# Altium schematic sheet 10 ADC.SchDoc
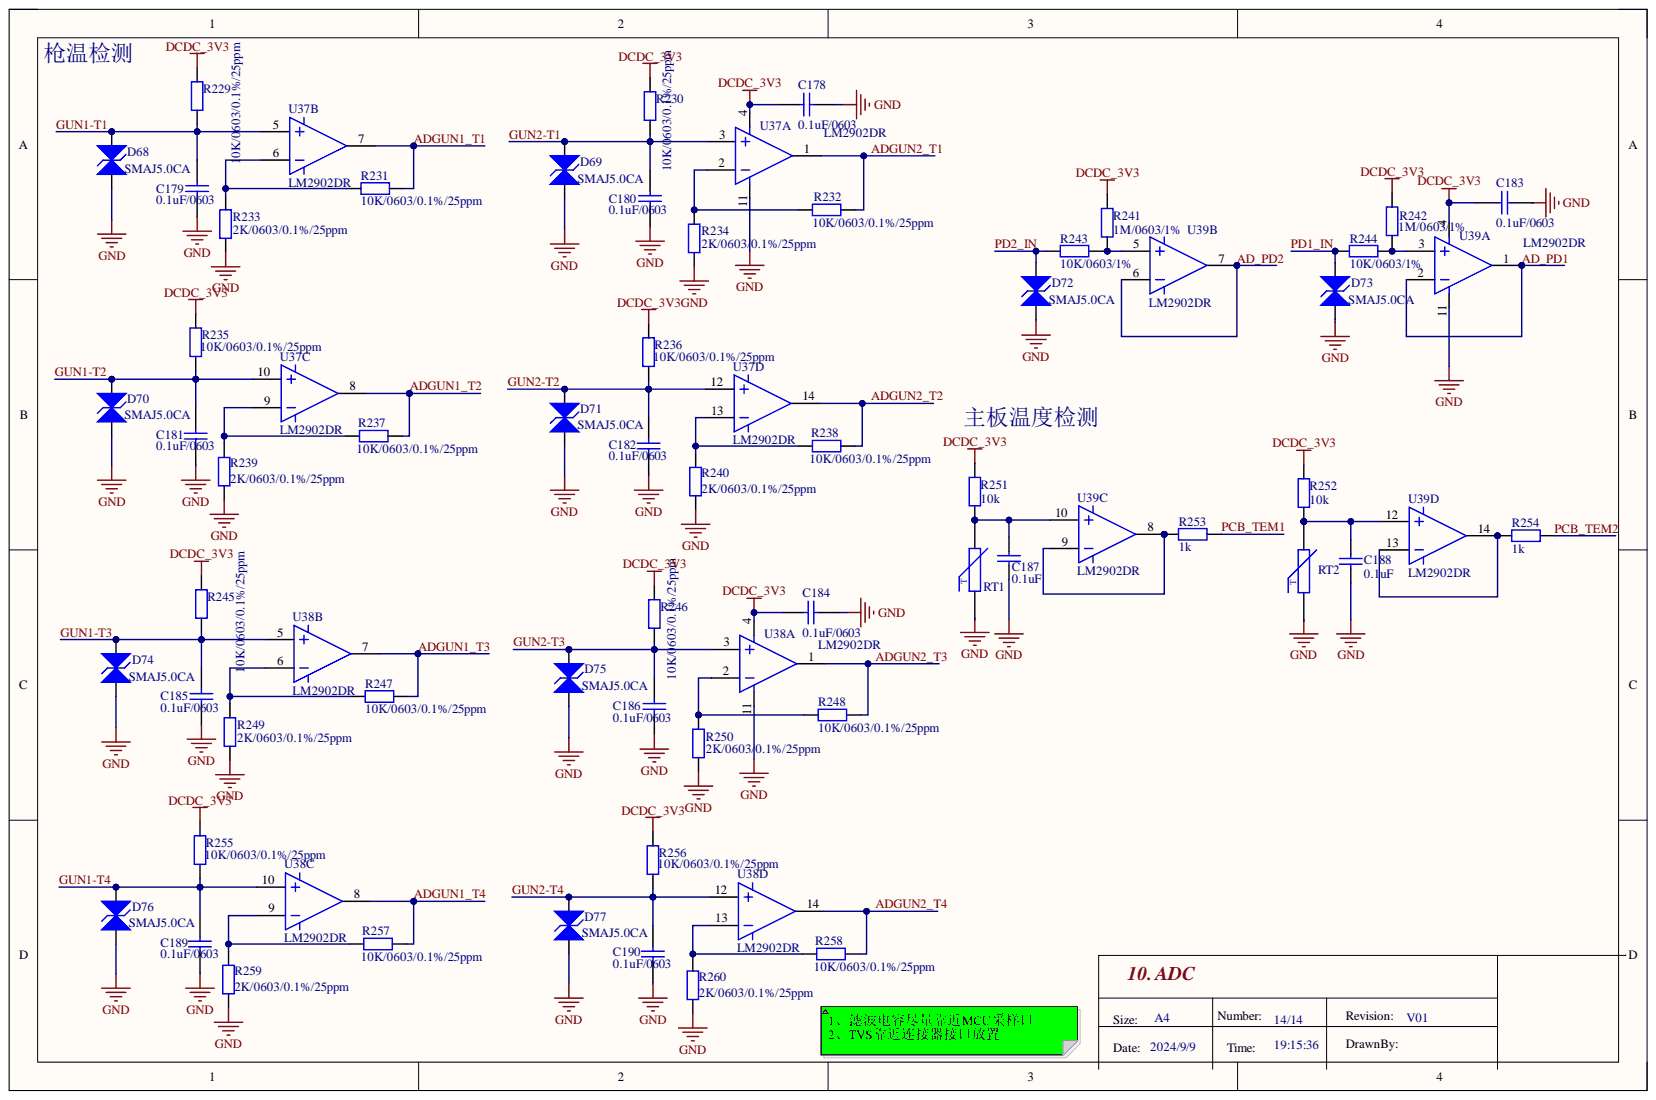
[diagram p0: 10 ADC.SchDoc]

## Parts
C178  0.1uF/0603  [C0603]
C179  0.1uF/0603  [C0603]
C180  0.1uF/0603  [C0603]
C181  0.1uF/0603  [C0603]
C182  0.1uF/0603  [C0603]
C183  0.1uF/0603  [C0603]
C184  0.1uF/0603  [C0603]
C185  0.1uF/0603  [C0603]
C186  0.1uF/0603  [C0603]
C187  0.1uF  [C0603]
C188  0.1uF  [C0603]
C189  0.1uF/0603  [C0603]
C190  0.1uF/0603  [C0603]
D68  SMAJ5.0CA  [SMA]
D69  SMAJ5.0CA  [SMA]
D70  SMAJ5.0CA  [SMA]
D71  SMAJ5.0CA  [SMA]
D72  SMAJ5.0CA  [SMA]
D73  SMAJ5.0CA  [SMA]
D74  SMAJ5.0CA  [SMA]
D75  SMAJ5.0CA  [SMA]
D76  SMAJ5.0CA  [SMA]
D77  SMAJ5.0CA  [SMA]
R229  10K/0603/0.1%/25ppm  [R0603]
R230  10K/0603/0.1%/25ppm  [R0603]
R231  10K/0603/0.1%/25ppm  [R0603]
R232  10K/0603/0.1%/25ppm  [R0603]
R233  2K/0603/0.1%/25ppm  [R0603]
R234  2K/0603/0.1%/25ppm  [R0603]
R235  10K/0603/0.1%/25ppm  [R0603]
R236  10K/0603/0.1%/25ppm  [R0603]
R237  10K/0603/0.1%/25ppm  [R0603]
R238  10K/0603/0.1%/25ppm  [R0603]
R239  2K/0603/0.1%/25ppm  [R0603]
R240  2K/0603/0.1%/25ppm  [R0603]
R241  1M/0603/1%  [R0603]
R242  1M/0603/1%  [R0603]
R243  10K/0603/1%  [R0603]
R244  10K/0603/1%  [R0603]
R245  10K/0603/0.1%/25ppm  [R0603]
R246  10K/0603/0.1%/25ppm  [R0603]
R247  10K/0603/0.1%/25ppm  [R0603]
R248  10K/0603/0.1%/25ppm  [R0603]
R249  2K/0603/0.1%/25ppm  [R0603]
R250  2K/0603/0.1%/25ppm  [R0603]
R251  10k  [R0603]
R252  10k  [R0603]
R253  1k  [R0603]
R254  1k  [R0603]
R255  10K/0603/0.1%/25ppm  [R0603]
R256  10K/0603/0.1%/25ppm  [R0603]
R257  10K/0603/0.1%/25ppm  [R0603]
R258  10K/0603/0.1%/25ppm  [R0603]
R259  2K/0603/0.1%/25ppm  [R0603]
R260  2K/0603/0.1%/25ppm  [R0603]
RT1  (no value)  [R0603]
RT2  (no value)  [R0603]
U37  LM2902DR  [SOIC-14_150mil]  (parts A,B,C,D)
U38  LM2902DR  [SOIC-14_150mil]  (parts A,B,C,D)
U39  LM2902DR  [SOIC-14_150mil]  (parts A,B,C,D)

## Nets
DCDC_3V3: C178.1 C183.1 C184.1 R229.2 R230.2 R235.2 R236.2 R241.2 R242.2 R245.2 R246.2 R251.1 R252.1 R255.2 R256.2 U37.4 U38.4 U39.4
GND: C178.2 C179.2 C180.2 C181.2 C182.2 C183.2 C184.2 C185.2 C186.2 C187.2 C188.2 C189.2 C190.2 D68.1 D69.1 D70.1 D71.1 D72.1 D73.1 D74.1 D75.1 D76.1 D77.1 R233.1 R234.1 R239.1 R240.1 R249.1 R250.1 R259.1 R260.1 RT1.1 RT2.1 U37.11 U38.11 U39.11
AD_PD1: U39.1 U39.2
AD_PD2: U39.6 U39.7
ADGUN1_T1: R231.2 U37.7
ADGUN1_T2: R237.2 U37.8
ADGUN1_T3: R247.2 U38.7
ADGUN1_T4: R257.2 U38.8
ADGUN2_T1: R232.2 U37.1
ADGUN2_T2: R238.2 U37.14
ADGUN2_T3: R248.2 U38.1
ADGUN2_T4: R258.2 U38.14
GUN1-T1: C179.1 D68.2 R229.1 U37.5
GUN1-T2: C181.1 D70.2 R235.1 U37.10
GUN1-T3: C185.1 D74.2 R245.1 U38.5
GUN1-T4: C189.1 D76.2 R255.1 U38.10
GUN2-T1: C180.1 D69.2 R230.1 U37.3
GUN2-T2: C182.1 D71.2 R236.1 U37.12
GUN2-T3: C186.1 D75.2 R246.1 U38.3
GUN2-T4: C190.1 D77.2 R256.1 U38.12
PCB_TEM1: R253.1
PCB_TEM2: R254.1
PD1_IN: D73.2 R244.2
PD2_IN: D72.2 R243.2
NetC187_1: C187.1 R251.2 RT1.2 U39.10
NetC188_1: C188.1 R252.2 RT2.2 U39.12
NetR231_1: R231.1 R233.2 U37.6
NetR232_1: R232.1 R234.2 U37.2
NetR237_1: R237.1 R239.2 U37.9
NetR238_1: R238.1 R240.2 U37.13
NetR241_1: R241.1 R243.1 U39.5
NetR242_1: R242.1 R244.1 U39.3
NetR247_1: R247.1 R249.2 U38.6
NetR248_1: R248.1 R250.2 U38.2
NetR253_2: R253.2 U39.8 U39.9
NetR254_2: R254.2 U39.13 U39.14
NetR257_1: R257.1 R259.2 U38.9
NetR258_1: R258.1 R260.2 U38.13

## Library data of the parts
C178-C186 C189 C190 = 0.1uF/50V/0603/X7R/TDK: 贴片电容,0.1unF-50V-X7R-0603-10%; 品牌: TDK; 型号规格: C1608X7R1H104KT000N; 焊接类型: SMT; Component Kind: Standard; ERP code: ELC.0348; Library Name: DH_Capacitance_Standard.SchLib
C187 C188 = Cap: 无极性电容; FootPoint: 0603; 厂: Samsung; Component Kind: Standard
D68-D77 = D_TVS2: TVS 扬杰 SMAJ5.0CA 5V 400W SMA 贴装; Component Kind: Standard; Library Name: DH_Device.SchLib
R229-R232 R235-R238 R245-R248 R255-R258 = 10K/0603/0.1%/25ppm: 贴片电阻,10K-1/10W-0603-0.1%-25ppm; 品牌: YAGEO; 型号规格: RT0603BRD07-10KL; 焊接类型: SMT; Component Kind: Standard; ERP Code: ELC.0324; Library Name: DH_Resistance_Standard.SchLib
R233 R234 R239 R240 R249 R250 R259 R260 = 2K/0603/0.1%/25ppm: 贴片电阻,2K-1/10W-0603-0.1%-25ppm; 品牌: YAGEO; 型号规格: RT0603BRD07-2KL; 焊接类型: SMT; Component Kind: Standard; ERP Code: ELC.0322; Library Name: DH_Resistance_Standard.SchLib
R241 R242 = 1M/0603/1%: 贴片电阻,1M-1/10W-0603-1%; 品牌: YAGEO; 型号规格: RC0603FR-071ML; 焊接类型: SMT; Component Kind: Standard; ERP Code: ELC.0434; Library Name: DH_Resistance_Standard.SchLib
R243 R244 = 10K/0603/1%: 贴片电阻,10K-1/10W-0603-1%; 品牌: YAGEO; 型号规格: RC0603FR-0710KL; 焊接类型: SMT; Component Kind: Standard; ERP code: ELC.0270; Library Name: DH_Resistance_Standard.SchLib
R251-R254 = Res: 电阻器; FootPoint: 0402; 厂商: 风华; 厂商编号: RS-03W102JT; Component Kind: Standard
RT1 RT2 = RT_NTC 22D-9: 热敏电阻; Component Kind: Standard; Library Name: SR集成库.IntLib
U37-U39 = LM2902DR: 运算放大器; 品牌: TI; 焊接类型: SMT; Component Kind: Standard; ERP Code: ELC.0087; Library Name: DH_Chip_Standard.SchLib; 型号规格: LM2902DR
